# Altium schematic sheet 5R_Supply.doc.SchDoc(5R_Supply.doc)
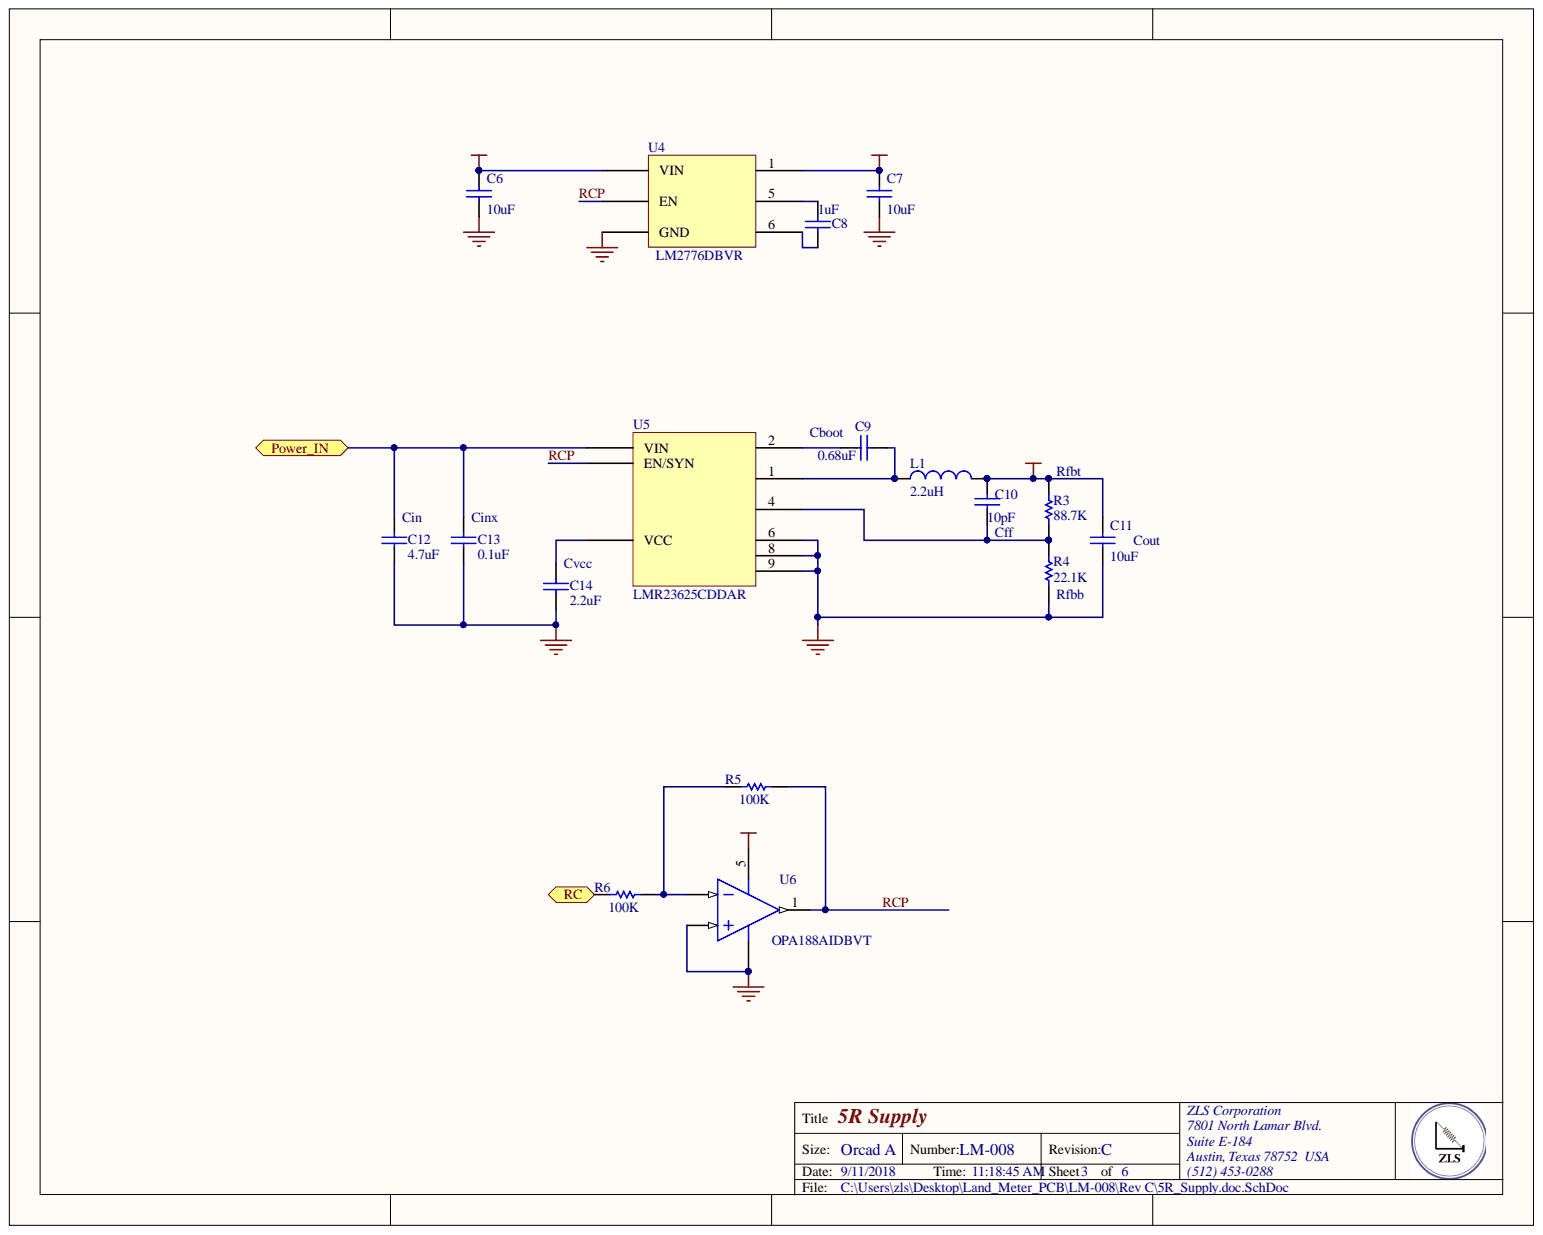
[diagram p0: 5R_Supply.doc.SchDoc]

## Parts
C6  10uF  [CAPC0805(2012)145_N]
C7  10uF  [CAPC0805(2012)145_N]
C8  1uF  [CAPC0805(2012)145_N]
C9  0.68uF  [1608[0603]]
C10  10pF  [CAPC0805(2012)145_N]
C11  10uF  [CAPC0805(2012)145_N]
C12  4.7uF  [C1210]
C13  0.1uF  [1608[0603]]
C14  2.2uF  [1608[0603]]
L1  2.2uH  [PA4332]
R3  88.7K  [RESC0805(2012)_M]
R4  22.1K  [RESC0805(2012)_M]
R5  Resistance: 100K  [RESC0805(2012)_M]  (hidden comment: RES SMD 100K OHM 1% 1/8W 0805)
R6  Resistance: 100K  [RESC0805(2012)_M]  (hidden comment: RES SMD 100K OHM 1% 1/8W 0805)
U4  LM2776DBVR  [LM2776DBVR]
U5  LMR23625CDDAR  [LMR23625CDDAR]
U6  OPA188AIDBVT  [DBV0005A_L]

## Ports
Power_IN, RC

## Nets
+5: C10.1 C11.1 L1.2 R3.2
+5 BBB: C6.1 U4.3(VIN) U6.5
-5: C7.1 U4.1
GND: C6.2 C7.2 C11.2 C12.1 C13.1 C14.1 R4.1 U4.2(GND) U5.6 U5.8 U5.9 U6.2 U6.3
Power_IN: C12.2 C13.2 U5.7(VIN) ; port Power_IN
RC: R6.1 ; port RC
RCP: R5.2 U4.4(EN) U5.5(EN/SYN) U6.1
NetC8_1: C8.1 U4.5
NetC8_2: C8.2 U4.6
NetC9_1: C9.1 U5.2
NetC9_2: C9.2 L1.1 U5.1
NetC10_2: C10.2 R3.1 R4.2 U5.4
NetC14_2: C14.2 U5.3(VCC)
NetR5_1: R5.1 R6.2 U6.4

## Library data of the parts
C6 C7 C11 = CMP-1036-04795-1: CAP CER 10UF 16V X6S 0805; Manufacturer Part Number: C2012X6S1C106K085AC; Manufacturer: TDK Corporation; Tolerance: ±10%; Notification: Current market demand for these product types have resulted in fluctuating and extending lead times. Lead times may differ.; Voltage - Rated: 16V; Thickness (Max): 0.039" (1.00mm); Temperature Coefficient: X6S; Supplier Part Number 1: 445-14483-6-ND; Supplier 1: Digi-Key; Stock 1: 0; Size / Dimension: 0.079" L x 0.049" W (2.00mm x 1.25mm); RoHS: RoHS Compliant; Ratings: -; Pricing 1: 1=0.38, 10=0.259, 100=0.1466, 500=0.1035, 1000=0.09056 (USD); Part Status: Active; Packaging: Digi-Reel®; Package / Case: 0805 (2012 Metric); Operating Temperature: -55°C ~ 105°C; Mounting Type: Surface Mount, MLCC; Library Name: Altium Content Vault; Lead Style: -; Lead Spacing: -; Height - Seated (Max): -; Features: Low ESL; Failure Rate: -; ComponentLink1URL: https://product.tdk.com/info/en/catalog/datasheets/mlcc_commercial_general_en.pdf; ComponentLink1Description: https://product.tdk.com/info/en/catalog/datasheets/mlcc_commercial_general_en.pdf; Component Kind: Standard; Category: Capacitors; Applications: General Purpose
C8 = CMP-1036-04795-1: CAP CER 1UF 25V X7R 0805; Manufacturer Part Number: CC0805KKX7R6BB105; Manufacturer: Yageo; Voltage - Rated: 10V; Tolerance: ±10%; Notification: Current market demand for these product types have resulted in fluctuating and extending lead times. Lead times may differ.; Thickness (Max): 0.057" (1.45mm); Temperature Coefficient: X7R; Supplier Part Number 1: 311-1458-6-ND; Supplier 1: Digi-Key; Stock 1: 30000; Size / Dimension: 0.079" L x 0.049" W (2.00mm x 1.25mm); RoHS: RoHS Compliant; Ratings: -; Pricing 1: 1=0.25, 10=0.168, 100=0.0852, 500=0.0583, 1000=0.05158 (USD); Part Status: Active; Packaging: Digi-Reel®; Package / Case: 0805 (2012 Metric); Operating Temperature: -55°C ~ 125°C; Mounting Type: Surface Mount, MLCC; Library Name: Altium Content Vault; Lead Style: -; Lead Spacing: -; Height - Seated (Max): -; Features: -; Failure Rate: -; ComponentLink1URL: http://www.yageo.com/documents/recent/UPY-GPHC_X7R_6.3V-to-50V_18.pdf; ComponentLink1Description: http://www.yageo.com/documents/recent/UPY-GPHC_X7R_6.3V-to-50V_18.pdf; Component Kind: Standard; Category: Capacitors; Applications: General Purpose
C9 = Cap Semi: CAP CER 0.68UF 50V X5R 0603; Manufacturer Part Number: C1608X5R1H684K080AB; Manufacturer: TDK Corporation; Tolerance: ±10%; Notification: Current market demand for these product types have resulted in fluctuating and extending lead times. Lead times may differ.; Voltage - Rated: 50V; Thickness (Max): 0.035" (0.90mm); Temperature Coefficient: X5R; Supplier Part Number 1: 445-7466-6-ND; Supplier 1: Digi-Key; Stock 1: 40000; Size / Dimension: 0.063" L x 0.032" W (1.60mm x 0.80mm); Simulation: CAP; Signal Integrity: Cap Semi; RoHS: RoHS Compliant; Ratings: -; Pricing 1: 1=0.24, 10=0.162, 100=0.0819, 500=0.05606, 1000=0.04959 (USD); Part Status: Not For New Designs; Packaging: Digi-Reel®; Package / Case: 0603 (1608 Metric); Operating Temperature: -55°C ~ 85°C; Mounting Type: Surface Mount, MLCC; Library Name: Miscellaneous Devices.IntLib; Lead Style: -; Lead Spacing: -; Height - Seated (Max): -; Features: Low ESL; Failure Rate: -; ComponentLink1URL: https://product.tdk.com/info/en/catalog/datasheets/mlcc_commercial_general_en.pdf; ComponentLink1Description: https://product.tdk.com/info/en/catalog/datasheets/mlcc_commercial_general_en.pdf; Component Kind: Standard; Category: Capacitors; Applications: General Purpose
C10 = CMP-1036-04795-1: CAP CER 10PF 16V C0G/NP0 0805; Manufacturer Part Number: C0805C100M4GACTU; Manufacturer: KEMET; Tolerance: ±20%; Temperature Coefficient: C0G, NP0; Notification: Current market demand for these product types have resulted in fluctuating and extending lead times. Lead times may differ.; Voltage - Rated: 16V; Thickness (Max): 0.035" (0.88mm); Supplier Part Number 1: 399-9147-6-ND; Supplier 1: Digi-Key; Stock 1: 7637; Size / Dimension: 0.079" L x 0.049" W (2.00mm x 1.25mm); RoHS: RoHS Compliant; Ratings: -; Pricing 1: 1=0.13, 10=0.092, 100=0.0409, 500=0.02918, 1000=0.02293 (USD); Part Status: Active; Packaging: Digi-Reel®; Package / Case: 0805 (2012 Metric); Operating Temperature: -55°C ~ 125°C; Mounting Type: Surface Mount, MLCC; Library Name: Altium Content Vault; Lead Style: -; Lead Spacing: -; Height - Seated (Max): -; Features: Low ESL; Failure Rate: -; ComponentLink1URL: https://api.kemet.com/component-edge/download/datasheet/C0805C100M4GACTU.pdf; ComponentLink1Description: https://api.kemet.com/component-edge/download/datasheet/C0805C100M4GACTU.pdf; Component Kind: Standard; Category: Capacitors; Applications: General Purpose
C12 = Cap Semi: CAP CER 4.7UF 50V X7R 1210; Manufacturer Part Number: GRM32ER71H475KA88L; Manufacturer: Murata Electronics North America; Tolerance: ±10%; Notification: Current market demand for these product types have resulted in fluctuating and extending lead times. Lead times may differ.; Voltage - Rated: 50V; Thickness (Max): 0.106" (2.70mm); Temperature Coefficient: X7R; Supplier Part Number 1: 490-1864-6-ND; Supplier 1: Digi-Key; Stock 1: 10000; Size / Dimension: 0.126" L x 0.098" W (3.20mm x 2.50mm); Simulation: CAP; Signal Integrity: Cap Semi; RoHS: RoHS Compliant; Ratings: -; Published: 8-Jun-2000; Pricing 1: 1=1.66, 10=1.313, 100=0.9849, 500=0.74414 (USD); Part Status: Not For New Designs; Packaging: Digi-Reel®; PackageReference: C1206; PackageDescription: Chip Capacitor; Package / Case: 1210 (3225 Metric); Operating Temperature: -55°C ~ 125°C; Mounting Type: Surface Mount, MLCC; Library Name: Miscellaneous Devices.IntLib; Lead Style: -; Lead Spacing: -; LatestRevisionNote: IPC-7351 Footprint Added.; LatestRevisionDate: 29-May-2009; Height - Seated (Max): -; Features: -; ComponentLink1URL: https://www.murata.com/~/media/webrenewal/support/library/catalog/products/capacitor/mlcc/c02e.ashx?la=en-us; ComponentLink1Description: https://www.murata.com/~/media/webrenewal/support/library/catalog/products/capacitor/mlcc/c02e.ashx?la=en-us; Component Kind: Standard; Category: Capacitors; Applications: General Purpose; Publisher: Altium Limited
C13 = Cap Semi: CAP CER 0.1UF 50V X5R 0603; Manufacturer Part Number: C1608X5R1H104K080AA; Manufacturer: TDK Corporation; Tolerance: ±10%; Notification: Current market demand for these product types have resulted in fluctuating and extending lead times. Lead times may differ.; Voltage - Rated: 50V; Thickness (Max): 0.035" (0.90mm); Temperature Coefficient: X5R; Supplier Part Number 1: 445-7456-6-ND; Supplier 1: Digi-Key; Stock 1: 0; Size / Dimension: 0.063" L x 0.032" W (1.60mm x 0.80mm); Simulation: CAP; Signal Integrity: Cap Semi; RoHS: RoHS Compliant; Ratings: -; Pricing 1: 1=0.1, 10=0.074, 100=0.0331, 500=0.02366, 1000=0.0186 (USD); Part Status: Not For New Designs; Packaging: Digi-Reel®; Package / Case: 0603 (1608 Metric); Operating Temperature: -55°C ~ 85°C; Mounting Type: Surface Mount, MLCC; Library Name: Miscellaneous Devices.IntLib; Lead Style: -; Lead Spacing: -; Height - Seated (Max): -; Features: Low ESL; Failure Rate: -; ComponentLink1URL: https://product.tdk.com/info/en/catalog/datasheets/mlcc_commercial_general_en.pdf; ComponentLink1Description: https://product.tdk.com/info/en/catalog/datasheets/mlcc_commercial_general_en.pdf; Component Kind: Standard; Category: Capacitors; Applications: General Purpose
C14 = Cap Semi: CAP CER 2.2UF 10V X5R 0603; Manufacturer Part Number: GRM188R61A225KE34D; Manufacturer: Murata Electronics North America; Tolerance: ±10%; Notification: Current market demand for these product types have resulted in fluctuating and extending lead times. Lead times may differ.; Voltage - Rated: 10V; Thickness (Max): 0.035" (0.90mm); Temperature Coefficient: X5R; Supplier Part Number 1: 490-1545-6-ND; Supplier 1: Digi-Key; Stock 1: 0; Size / Dimension: 0.063" L x 0.032" W (1.60mm x 0.80mm); Simulation: CAP; Signal Integrity: Cap Semi; RoHS: RoHS Compliant; Ratings: -; Pricing 1: 1=0.21 (USD); Part Status: Last Time Buy; Packaging: Digi-Reel®; Package / Case: 0603 (1608 Metric); Operating Temperature: -55°C ~ 85°C; Mounting Type: Surface Mount, MLCC; Library Name: Miscellaneous Devices.IntLib; Lead Style: -; Lead Spacing: -; Height - Seated (Max): -; Features: -; ComponentLink1URL: https://www.murata.com/~/media/webrenewal/support/library/catalog/products/capacitor/mlcc/c02e.ashx?la=en-us; ComponentLink1Description: https://www.murata.com/~/media/webrenewal/support/library/catalog/products/capacitor/mlcc/c02e.ashx?la=en-us; Component Kind: Standard; Category: Capacitors; Applications: General Purpose
L1 = Inductor: FIXED IND 2.2UH 4.3A 48 MOHM SMD; Manufacturer Part Number: PA4332.222NLT; Manufacturer: Pulse Electronics Power; Type: Wirewound; Tolerance: ±20%; Shielding: Shielded; Material - Core: Iron; Frequency - Self Resonant: 25MHz; DC Resistance (DCR): 48 mOhm Max; Current - Saturation: 6.1A; Supplier Part Number 1: 553-3290-6-ND; Supplier Device Package: -; Supplier 1: Digi-Key; Stock 1: 4798; Size / Dimension: 0.165" L x 0.165" W (4.20mm x 4.20mm); Simulation: INDUCTOR; Signal Integrity: Inductor; RoHS: RoHS Compliant; Ratings: -; Q @ Freq: -; Pricing 1: 1=0.64, 10=0.564, 100=0.4025, 500=0.3381, 1000=0.2737 (USD); Part Status: Active; Packaging: Digi-Reel®; Package / Case: Nonstandard; Operating Temperature: -40°C ~ 125°C; Mounting Type: Surface Mount; Library Name: Miscellaneous Devices.IntLib; Height - Seated (Max): 0.079" (2.00mm); Features: -; ComponentLink1URL: http://products.pulseelex.com/files/product_files/P761.pdf; ComponentLink1Description: http://products.pulseelex.com/files/product_files/P761.pdf; Component Kind: Standard; Category: Inductors, Coils, Chokes
R3 = CMP-1013-00017-1: RES SMD 88.7K OHM 1% 1/8W 0805; Manufacturer Part Number: CRCW080588K7FKEA; Manufacturer: Vishay Dale; Temperature Coefficient: ±100ppm/°C; Power (Watts): 0.125W, 1/8W; Tolerance: ±1%; Supplier Part Number 1: 541-88.7KCDKR-ND; Supplier Device Package: 0805; Supplier 1: Digi-Key; Stock 1: 66; Size / Dimension: 0.079" L x 0.049" W (2.00mm x 1.25mm); RoHS: RoHS Compliant; Pricing 1: 1=0.1, 10=0.055, 100=0.0223, 1000=0.01003, 2500=0.0087 (USD); Part Status: Active; Packaging: Digi-Reel®; Package / Case: 0805 (2012 Metric); Operating Temperature: -55°C ~ 155°C; Library Name: Altium Content Vault; Height - Seated (Max): 0.020" (0.50mm); Features: Automotive AEC-Q200; Failure Rate: -; Composition: Thick Film; ComponentLink1URL: http://www.vishay.com/docs/20035/dcrcwe3.pdf; ComponentLink1Description: http://www.vishay.com/docs/20035/dcrcwe3.pdf; Component Kind: Standard; Category: Resistors
R4 = CMP-1013-00017-1: RES SMD 22.1K OHM 1% 1/8W 0805; Manufacturer Part Number: CRCW080522K1FKEA; Manufacturer: Vishay Dale; Temperature Coefficient: ±100ppm/°C; Power (Watts): 0.125W, 1/8W; Tolerance: ±1%; Supplier Part Number 1: 541-22.1KCDKR-ND; Supplier Device Package: 0805; Supplier 1: Digi-Key; Stock 1: 1994; Size / Dimension: 0.079" L x 0.049" W (2.00mm x 1.25mm); RoHS: RoHS Compliant; Pricing 1: 1=0.1, 10=0.055, 100=0.0223, 1000=0.01003, 2500=0.0087 (USD); Part Status: Active; Packaging: Digi-Reel®; Package / Case: 0805 (2012 Metric); Operating Temperature: -55°C ~ 155°C; Library Name: Altium Content Vault; Height - Seated (Max): 0.020" (0.50mm); Features: Automotive AEC-Q200; Failure Rate: -; Composition: Thick Film; ComponentLink1URL: http://www.vishay.com/docs/20035/dcrcwe3.pdf; ComponentLink1Description: http://www.vishay.com/docs/20035/dcrcwe3.pdf; Component Kind: Standard; Category: Resistors
R5 R6 = CMP-1013-00017-1: Manufacturer Part Number: CRCW0805100KFKEA; Manufacturer: Vishay; Voltage Rating (DC): 150V; Temperature Coefficient: 100ppm/°C; Power Rating: 125mW; Width: 1.25mm; Weight: 0.000194oz; Voltage Rating: 150V; Tolerance: 1%; Termination: SMD/SMT; Supplier Part Number 1: CRCW0805100KFKEA/BKN; Supplier 1: Avnet; Stock 1: 1317; REACH SVHC: Unknown; Radiation Hardening: No; Pricing 1: 1=0.1, 10=0.049, 25=0.042, 50=0.035, 100=0.028 (USD); Packaging: Tape and Reel; Package Quantity: 5000; Number of Pins: 2; Mount: Surface Mount; Min Operating Temperature: -55°C; Military Standard: Not; Max Operating Temperature: 155°C; Library Name: Altium Content Vault; Length: 2mm; Lead Free: Lead Free; Height: 0.45mm; Description: RES SMD 100K OHM 1% 1/8W 0805; Depth: 1.25mm; Contact Plating: Tin; Composition: Thick Film; ComponentLink9URL: https://datasheet.ciiva.com/7777/1720486-7777565.pdf; ComponentLink9Description: https://datasheet.ciiva.com/7777/1720486-7777565.pdf; ComponentLink8URL: https://datasheet.ciiva.com/16720/70201119-16720891.pdf; ComponentLink8Description: https://datasheet.ciiva.com/16720/70201119-16720891.pdf; ComponentLink7URL: https://datasheet.ciiva.com/16852/dcrcwe3-16852810.pdf; ComponentLink7Description: https://datasheet.ciiva.com/16852/dcrcwe3-16852810.pdf; ComponentLink6URL: https://datasheet.ciiva.com/16341/dcrcwe3-16341530.pdf; ComponentLink6Description: https://datasheet.ciiva.com/16341/dcrcwe3-16341530.pdf; ComponentLink5URL: https://datasheet.ciiva.com/8337/dcrcwe3-8337738.pdf; ComponentLink5Description: https://datasheet.ciiva.com/8337/dcrcwe3-8337738.pdf; ComponentLink4URL: https://datasheet.ciiva.com/16754/70201119-16754822.pdf; ComponentLink4Description: https://datasheet.ciiva.com/16754/70201119-16754822.pdf; ComponentLink3URL: https://datasheet.ciiva.com/3258/dcrcwe3-3258006.pdf; ComponentLink3Description: https://datasheet.ciiva.com/3258/dcrcwe3-3258006.pdf; ComponentLink2URL: https://datasheet.ciiva.com/7777/1697337-7777349.pdf; ComponentLink2Description: https://datasheet.ciiva.com/7777/1697337-7777349.pdf; ComponentLink1URL: https://datasheet.ciiva.com/11809/0900766b80d98b26-11809057.pdf; ComponentLink1Description: https://datasheet.ciiva.com/11809/0900766b80d98b26-11809057.pdf; Component Kind: Standard; Case Code (Metric): 2012; Case Code (Imperial): 0805; Case/Package: 0805
U4 = LM2776DBVR: IC REG SWTCHD CAP INV 6SOT23; Manufacturer: Texas Instruments; Voltage - Output (Min/Fixed): -Vin; Voltage - Input (Min): 2.7V; Voltage - Input (Max): 5.5V; Topology: Charge Pump; Synchronous Rectifier: No; Output Type: Fixed; Output Configuration: Negative; Number of Outputs: 1; Function: Ratiometric; Frequency - Switching: 2MHz; Current - Output: 200mA; Voltage - Output (Max): -; Supplier Part Number 1: 296-43957-6-ND; Supplier Device Package: SOT-23-6; Supplier 1: Digi-Key; Stock 1: 13045; RoHS: RoHS Compliant; Pricing 1: 1=1.05, 10=0.936, 100=0.7297, 500=0.60276, 1000=0.47586 (USD); Part Status: Active; Packaging: Digi-Reel®; Package / Case: SOT-23-6; Operating Temperature: -40°C ~ 85°C (TA); Mounting Type: Surface Mount; Manufacturer Part Number: LM2776DBVR; ComponentLink1URL: http://www.ti.com/lit/ds/symlink/lm2776.pdf; ComponentLink1Description: http://www.ti.com/lit/ds/symlink/lm2776.pdf; Component Kind: Standard; Category: Integrated Circuits (ICs); Library Name: ZLS_Schematic_lib.SchLib
U5 = LMR23625CDDAR: IC REG BUCK ADJ 2.5A SYNC 8SOPWR; Manufacturer: Texas Instruments; Voltage - Output (Min/Fixed): 1V; Voltage - Output (Max): 28V; Voltage - Input (Min): 4.5V; Voltage - Input (Max): 36V; Synchronous Rectifier: Yes; Output Type: Adjustable; Output Configuration: Positive; Number of Outputs: 1; Function: Step-Down; Frequency - Switching: 2.1MHz; Topology: Buck; Supplier Part Number 1: 296-47268-1-ND; Supplier Device Package: 8-SO PowerPad; Supplier 1: Digi-Key; Stock 1: 0; RoHS: RoHS Compliant; Pricing 1: 1=3.24, 10=2.912, 100=2.3861, 500=2.03124, 1000=1.7131 (USD); Part Status: Active; Packaging: Cut Tape (CT); Package / Case: 8-PowerSOIC (0.154", 3.90mm Width); Operating Temperature: -40°C ~ 125°C (TJ); Mounting Type: Surface Mount; Manufacturer Part Number: LMR23625CDDAR; Current - Output: 2.5A; ComponentLink1URL: http://www.ti.com/lit/ds/symlink/lmr23625.pdf; ComponentLink1Description: http://www.ti.com/lit/ds/symlink/lmr23625.pdf; Component Kind: Standard; Category: Integrated Circuits (ICs); Library Name: ZLS_Schematic_lib.SchLib
U6 = CMP-0809-00006-2: IC OPAMP ZERO-DRIFT 2MHZ SOT23-5; Manufacturer: Texas Instruments; Voltage - Supply, Single/Dual (±): 4 V ~ 36 V, ±2 V ~ 18 V; Slew Rate: 0.8 V/µs; Output Type: Rail-to-Rail; Current - Supply: 450µA; Supplier Part Number 1: 296-36218-1-ND; Supplier Device Package: SOT-23-5; Supplier 1: Digi-Key; Stock 1: 8627; RoHS: RoHS Compliant; Pricing 1: 1=2.83, 10=2.541, 100=2.0425 (USD); Part Status: Active; Packaging: Cut Tape (CT); Package / Case: SC-74A, SOT-753; Operating Temperature: -40°C ~ 125°C; Mounting Type: Surface Mount; Manufacturer Part Number: OPA188AIDBVT; Library Name: Altium Content Vault; ComponentLink1URL: http://www.ti.com/lit/ds/symlink/opa188.pdf; ComponentLink1Description: http://www.ti.com/lit/ds/symlink/opa188.pdf; Component Kind: Standard; Category: Integrated Circuits (ICs); Base Part Number: OPA188; Amplifier Type: Zero-Drift
